# Altium schematic sheet JTAG&POWER.SchDoc(JTAG&POWER)
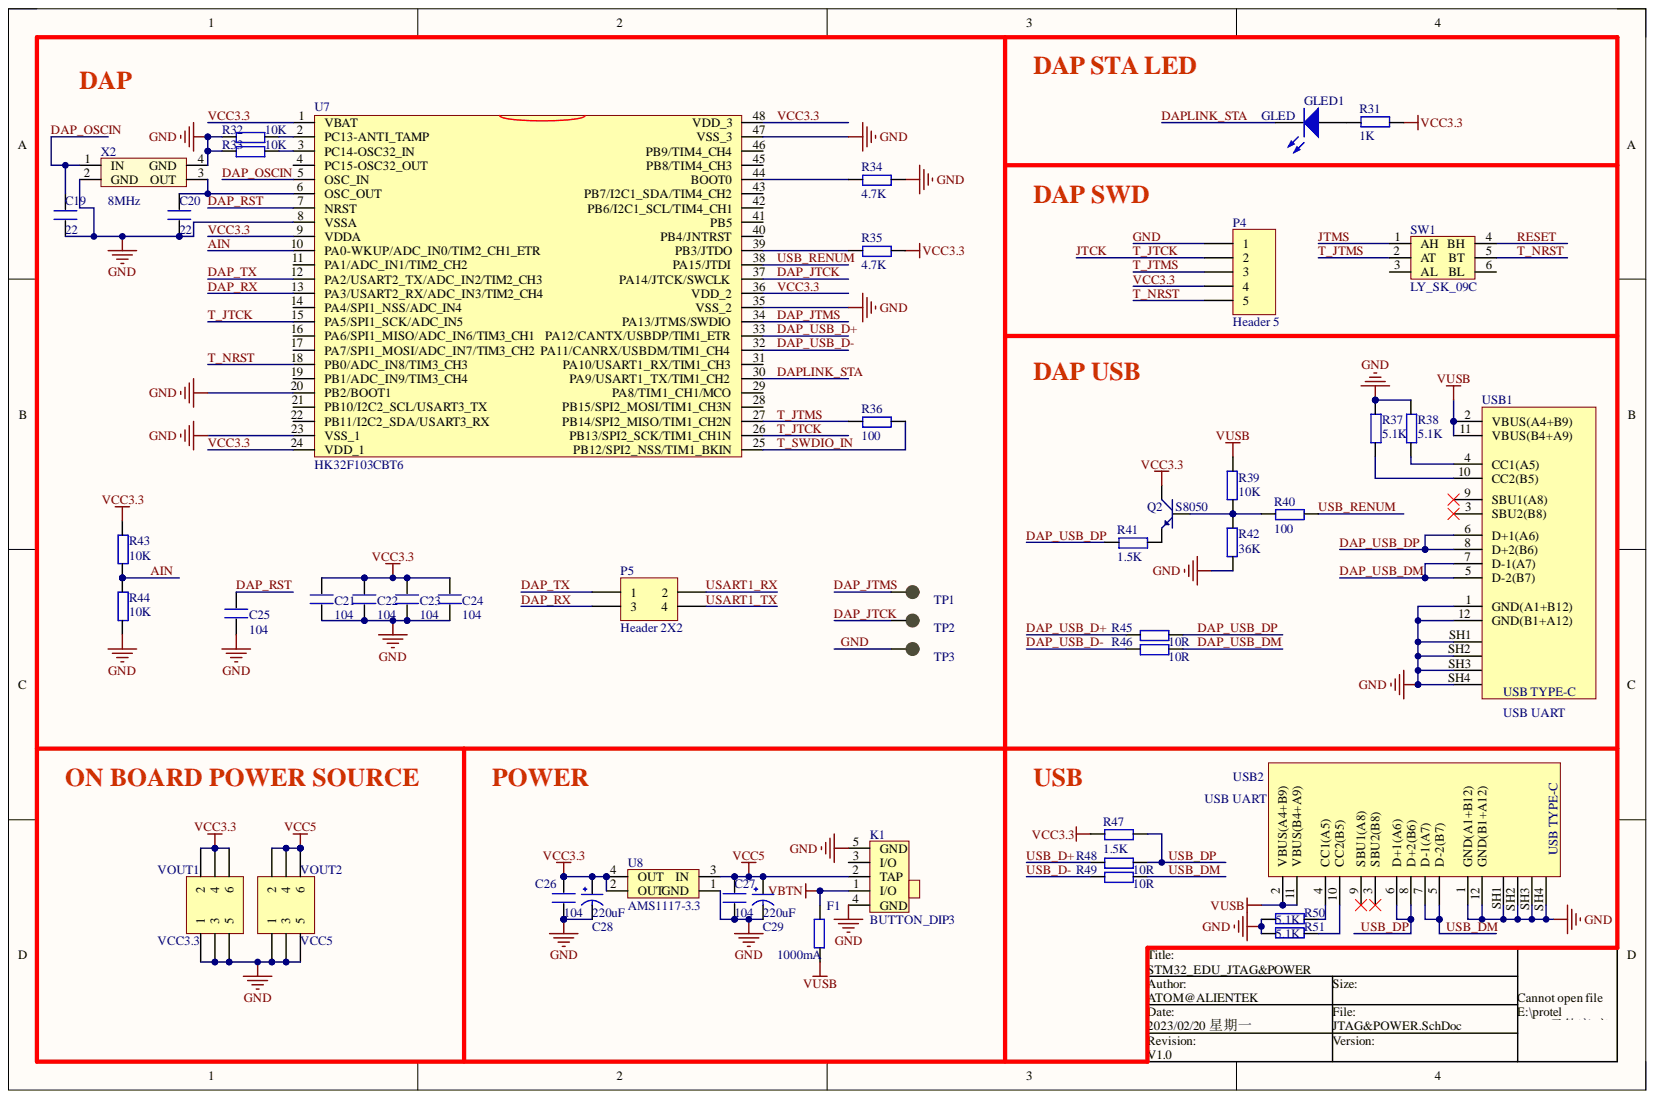
[diagram p0: JTAG&POWER.SchDoc]

## Parts
C19  22  [0603P]
C20  22  [0603P]
C21  104  [0603P]
C22  104  [0603P]
C23  104  [0603P]
C24  104  [0603P]
C25  104  [0603P]
C26  104  [0603P]
C27  104  [0603P]
C28  220uF  [CC6.56.5]
C29  220uF  [CC6.56.5]
F1  1000mA  [0805]
GLED  GLED1  [SMDLED]
GND  TP3  [TP-1.5]
K1  BUTTON_DIP3  [BTN8.8*4.6]
P4  Header 5  [HDR1X5]
P5  Header 2X2  [HDR2X2]
Q2  S8050  [SOT-23R]
R31  1K  [0603P]
R32  10K  [0603P]
R33  10K  [0603P]
R34  4.7K  [0603P]
R35  4.7K  [0603P]
R36  100  [0603P]
R37  5.1K  [0603P]
R38  5.1K  [0603P]
R39  10K  [0603P]
R40  100  [0603P]
R41  1.5K  [0603P]
R42  36K  [0603P]
R43  10K  [0603P]
R44  10K  [0603P]
R45  10R  [0603P]
R46  10R  [0603P]
R47  1.5K  [0603P]
R48  10R  [0603P]
R49  10R  [0603P]
R50  5.1K  [0603P]
R51  5.1K  [0603P]
SW1  LY_SK_09C  [SW_LY_SK_09C]
SWC  TP2  [TP-1.5]
SWD  TP1  [TP-1.5]
U7  HK32F103CBT6  [mTQFP48]
U8  AMS1117-3.3  [AMS1117]
USB1  USB UART  [USB Type-C]
USB2  USB UART  [USB Type-C]
VOUT1  VCC3.3  [HDR2X3]
VOUT2  VCC5  [HDR2X3]
X2  8MHz  [XTAL3225   无源]

## Nets
VBTN: F1.1 K1.1(I/O)
VCC5: C27.2 C29.1 K1.2(TAP) U8.3(IN) VOUT2.2 VOUT2.4 VOUT2.6
VUSB: F1.2 R39.1 USB1.2(VBUS(A4+B9)) USB1.11(VBUS(B4+A9)) USB2.2(VBUS(A4+B9)) USB2.11(VBUS(B4+A9))
AIN: R43.1 R44.2 U7.10(PA0-WKUP/ADC_IN0/TIM2_CH1_ETR)
DAP_JTCK: SWC.1 U7.37(PA14/JTCK/SWCLK)
DAP_JTMS: SWD.1 U7.34(PA13/JTMS/SWDIO)
DAP_OSCIN: C19.2 U7.5(OSC_IN) X2.1(IN)
DAP_RST: C25.1 U7.7(NRST)
DAP_RX: P5.3 U7.13(PA3/USART2_RX/ADC_IN3/TIM2_CH4)
DAP_TX: P5.1 U7.12(PA2/USART2_TX/ADC_IN2/TIM2_CH3)
DAP_USB_D+: R45.1 U7.33(PA12/CANTX/USBDP/TIM1_ETR)
DAP_USB_D-: R46.1 U7.32(PA11/CANRX/USBDM/TIM1_CH4)
DAP_USB_DM: R46.2 USB1.5(D-2(B7)) USB1.7(D-1(A7))
DAP_USB_DP: R41.1 R45.2 USB1.6(D+1(A6)) USB1.8(D+2(B6))
DAPLINK_STA: GLED.2 U7.30(PA9/USART1_TX/TIM1_CH2)
GND: C19.1 C20.1 C21.2 C22.2 C23.2 C24.2 C25.2 C26.1 C27.1 C28.2 C29.2 GND.1 K1.4(GND) K1.5(GND) P4.1 R32.1 R33.1 R34.2 R37.2 R38.2 R42.2 R44.1 R50.2 R51.2 U7.8(VSSA) U7.20(PB2/BOOT1) U7.23(VSS_1) U7.35(VSS_2) U7.47(VSS_3) U8.1(GND) USB1.1(GND(A1+B12)) USB1.12(GND(B1+A12)) USB1.SH1(Shield1) USB1.SH2(Shield2) USB1.SH3(Shield3) USB1.SH4(Shield4) USB2.1(GND(A1+B12)) USB2.12(GND(B1+A12)) USB2.SH1(Shield1) USB2.SH2(Shield2) USB2.SH3(Shield3) USB2.SH4(Shield4) VOUT1.1 VOUT1.3 VOUT1.5 VOUT2.1 VOUT2.3 VOUT2.5 X2.2(GND) X2.4(GND)
JTCK: P4.2 U7.15(PA5/SPI1_SCK/ADC_IN5) U7.26(PB13/SPI2_SCK/TIM1_CH1N)
JTMS: SW1.1(AH)
RESET: SW1.4(BH)
T_JTMS: P4.3 R36.1 SW1.2(AT) U7.27(PB14/SPI2_MISO/TIM1_CH2N)
T_NRST: P4.5 SW1.5(BT) U7.18(PB0/ADC_IN8/TIM3_CH3)
T_SWDIO_IN: R36.2 U7.25(PB12/SPI2_NSS/TIM1_BKIN)
USART1_RX: P5.2
USART1_TX: P5.4
USB_D+: R48.1
USB_D-: R49.1
USB_DM: R49.2 USB2.5(D-2(B7)) USB2.7(D-1(A7))
USB_DP: R47.2 R48.2 USB2.6(D+1(A6)) USB2.8(D+2(B6))
USB_RENUM: R40.2 U7.38(PA15/JTDI)
VCC3.3: C21.1 C22.1 C23.1 C24.1 C26.2 C28.1 P4.4 Q2.3 R31.1 R35.2 R43.2 R47.1 U7.1(VBAT) U7.9(VDDA) U7.24(VDD_1) U7.36(VDD_2) U7.48(VDD_3) U8.2(OUT) U8.4(OUT) VOUT1.2 VOUT1.4 VOUT1.6
NetC20_2: C20.2 U7.6(OSC_OUT) X2.3(OUT)
NetGLED_1: GLED.1 R31.2
NetQ2_1: Q2.1 R41.2
NetQ2_2: Q2.2 R39.2 R40.1 R42.1
NetR32_2: R32.2 U7.2(PC13-ANTI_TAMP)
NetR33_2: R33.2 U7.3(PC14-OSC32_IN)
NetR34_1: R34.1 U7.44(BOOT0)
NetR35_1: R35.1 U7.39(PB3/JTDO)
NetR37_1: R37.1 USB1.10(CC2(B5))
NetR38_1: R38.1 USB1.4(CC1(A5))
NetR50_1: R50.1 USB2.4(CC1(A5))
NetR51_1: R51.1 USB2.10(CC2(B5))

Not connected: K1 3(I/O); SW1 3(AL) 6(BL); U7 4(PC15-OSC32_OUT) 11(PA1/ADC_IN1/TIM2_CH2) 14(PA4/SPI1_NSS/ADC_IN4) 16(PA6/SPI1_MISO/ADC_IN6/TIM3_CH1) 17(PA7/SPI1_MOSI/ADC_IN7/TIM3_CH2) 19(PB1/ADC_IN9/TIM3_CH4) 21(PB10/I2C2_SCL/USART3_TX) 22(PB11/I2C2_SDA/USART3_RX) 28(PB15/SPI2_MOSI/TIM1_CH3N) 29(PA8/TIM1_CH1/MCO) 31(PA10/USART1_RX/TIM1_CH3) 40(PB4/JNTRST) 41(PB5) 42(PB6/I2C1_SCL/TIM4_CH1) 43(PB7/I2C1_SDA/TIM4_CH2) 45(PB8/TIM4_CH3) 46(PB9/TIM4_CH4); USB1 3(SBU2(B8)) 9(SBU1(A8)); USB2 3(SBU2(B8)) 9(SBU1(A8))

## Library data of the parts
C19-C27 = C: Component Kind: Standard; Library Name: MYCOMLIB.IntLib
C28 C29 = CAP: Component Kind: Standard; Library Name: MYCOMLIB.IntLib
F1 R31-R51 = R: Component Kind: Standard
  F1 R45 R46 R48 R49: Library Name: MYCOMLIB.IntLib
  R31-R44 R47 R50 R51: Library Name: ALIENTEK_COMPONENT.IntLib
GLED = LED2: Typical RED, GREEN, YELLOW, AMBER GaAs LED; Component Kind: Standard; LatestRevisionDate: 17-Jul-2002; LatestRevisionNote: Re-released for DXP Platform.; Library Name: Miscellaneous Devices.IntLib; PackageDocument: Feb-2002; PackageReference: 3.2X1.6X1.1; Published: 8-Jun-2000; Simulation: LED2; Publisher: Altium Limited
GND SWC SWD = TEST-POINT: 测试点; Component Kind: Standard; Library Name: ALIENTEK_COMPONENT.IntLib
P4 = Header 5: Header, 5-Pin; Component Kind: Standard; LatestRevisionDate: 17-Jul-2002; LatestRevisionNote: Re-released for DXP Platform.; Library Name: Miscellaneous Connectors.IntLib; Signal Integrity: Connector; Publisher: Altium Limited
P5 = Header 2X2: Header, 2-Pin, Dual row; Component Kind: Standard; LatestRevisionDate: 17-Jul-2002; LatestRevisionNote: Re-released for DXP Platform.; Library Name: Miscellaneous Connectors.IntLib; Signal Integrity: Connector; Publisher: Altium Limited
Q2 = NPN: 8050/BCW846/BCW847; Component Kind: Standard; Library Name: ALIENTEK_COMPONENT.IntLib
SW1 = LY_SK_09C: 侧贴  拨动开关 2档 2位 9.1*3.5mm; Component Kind: Standard; Library Name: ALIENTEK_COMPONENT.IntLib
U7 = STM32F103C8: Component Kind: Standard; Library Name: ALIENTEK_COMPONENT.IntLib
U8 = AMS1117: Component Kind: Standard; Library Name: MYCOMLIB.IntLib
USB1 USB2 = USB Type-C: 12P TypeC 母座; Component Kind: Standard; Library Name: WARSHIP_STM32_V4.4.IntLib
VOUT1 VOUT2 = Header 3X2: Header, 3-Pin, Dual row; Component Kind: Standard; LatestRevisionDate: 17-Jul-2002; LatestRevisionNote: Re-released for DXP Platform.; Library Name: Miscellaneous Connectors.IntLib; Publisher: Altium Limited
X2 = XTAL-无源贴片: 无源贴片晶振; Component Kind: Standard; Library Name: MiniFly .IntLib
K1 = BUTTON_DIP3: Component Kind: Standard; Library Name: ALIENTEK_COMPONENT.IntLib
